# Altium schematic sheet Motor Drive.SchDoc
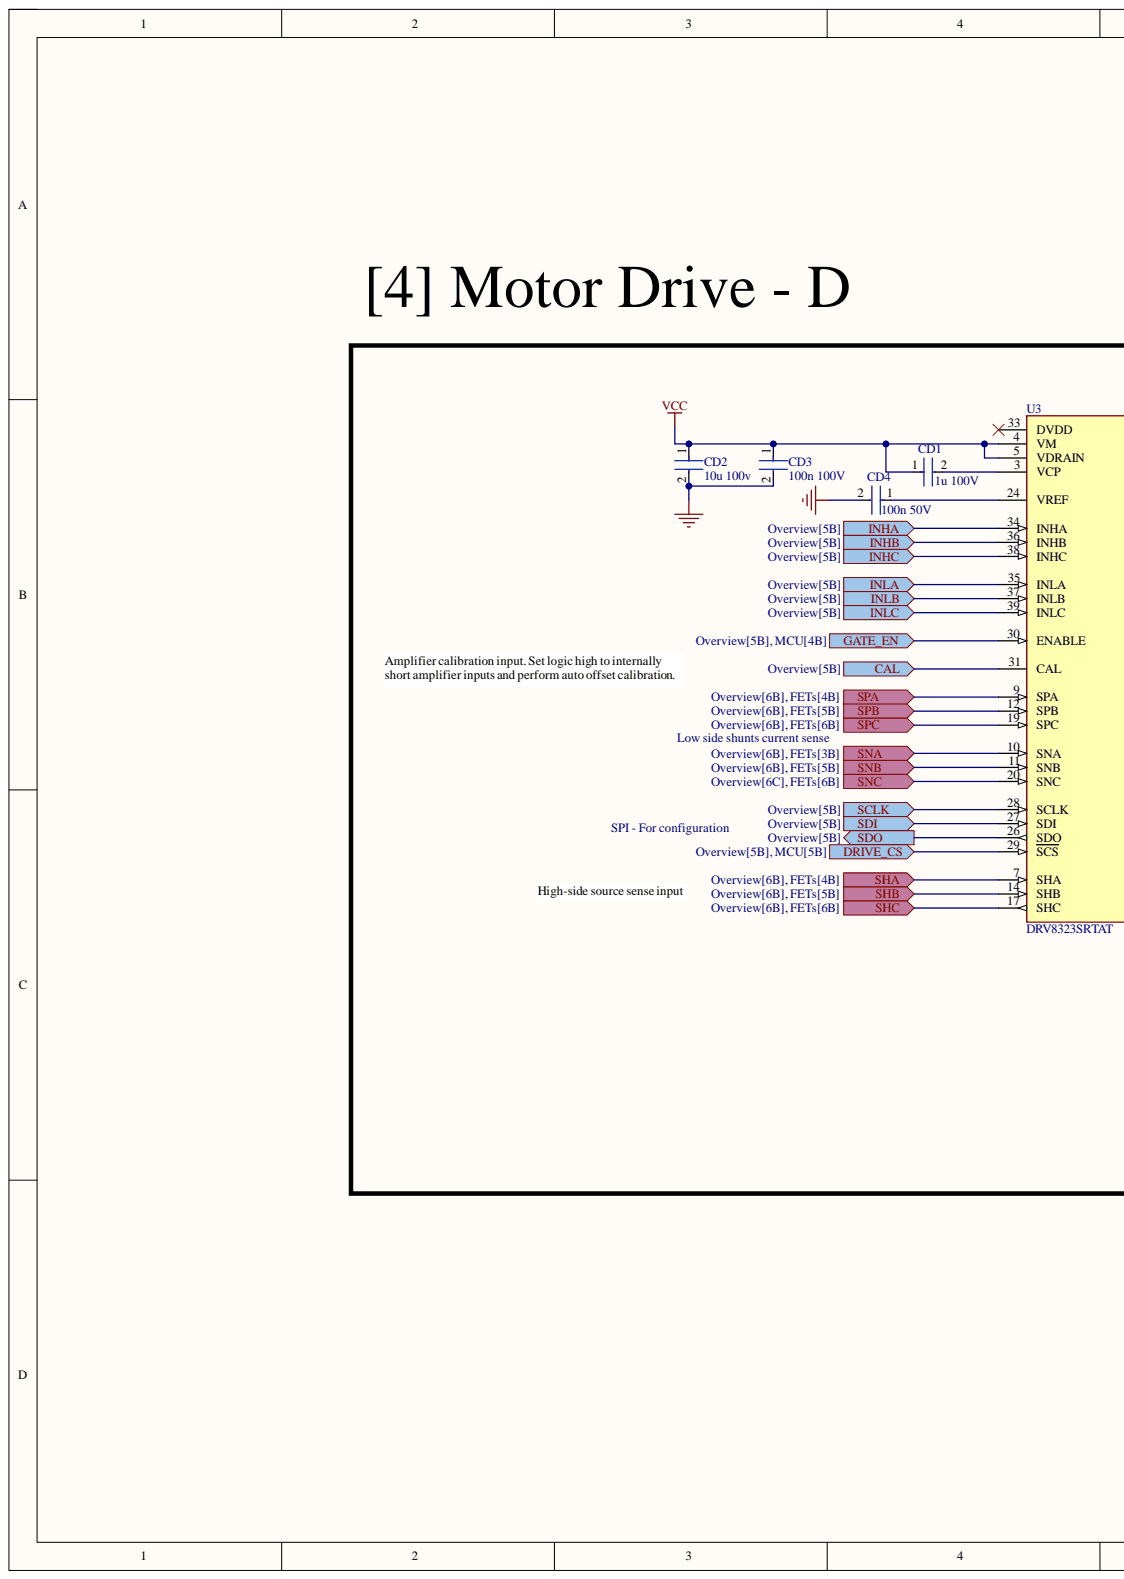
[diagram p0: Motor Drive.SchDoc, left]
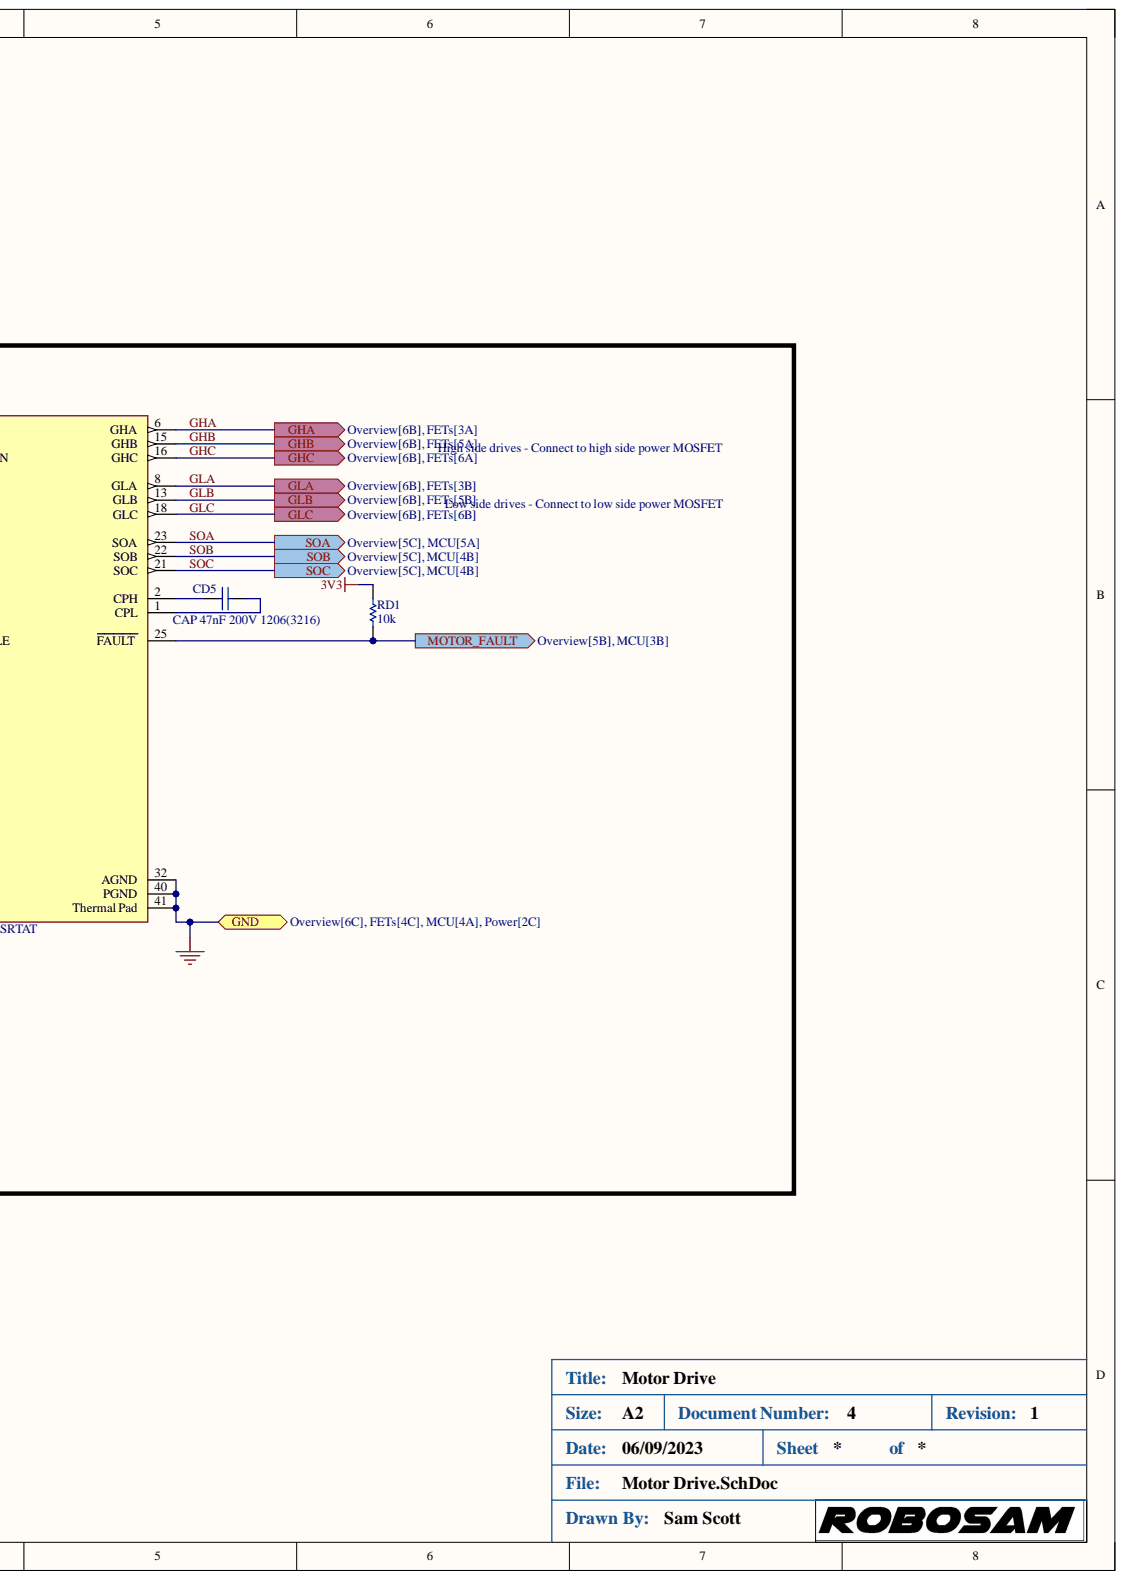
[diagram p1: Motor Drive.SchDoc, right]

## Parts
CD1  1u 100V  Voltage Rating: 100V  [FP-1206-L_3_2_0_15-W_1_6-IPC_A]
CD2  10u 100v  [FP-GRM32E-0_3-0_2-MFG]
CD3  100n 100V  Voltage Rating: 100V  [FP-CL21-IPC_A]
CD4  100n 50V  Voltage Rating: 50V  [FP-CL10-IPC_A]
CD5  CAP 47nF 200V 1206(3216)  value 47nF  [CAPC1206(3216)130_M]
RD1  10k  [RESC1609X50X30NL8T20]
U3  DRV8323SRTAT  [FP-RTA0040B-MFG]

## Ports
CAL, DRIVE_CS, GATE_EN, GHA, GHB, GHC, GLA, GLB, GLC, GND, INHA, INHB, INHC, INLA, INLB, INLC, MOTOR_FAULT, SCLK, SDI, SDO, SHA, SHB, SHC, SNA, SNB, SNC, SOA, SOB, SOC, SPA, SPB, SPC

## Nets
3V3: RD1.2
VCC: CD1.1 CD2.1 CD3.1 U3.4(VM) U3.5(VDRAIN)
CAL: U3.31(CAL) ; port CAL
DRIVE_CS: U3.29(SCS) ; port DRIVE_CS
GATE_EN: U3.30(ENABLE) ; port GATE_EN
GHA: U3.6(GHA) ; port GHA
GHB: U3.15(GHB) ; port GHB
GHC: U3.16(GHC) ; port GHC
GLA: U3.8(GLA) ; port GLA
GLB: U3.13(GLB) ; port GLB
GLC: U3.18(GLC) ; port GLC
GND: CD2.2 CD3.2 CD4.2 U3.32(AGND) U3.40(PGND) U3.41(Thermal_Pad) ; port GND
INHA: U3.34(INHA) ; port INHA
INHB: U3.36(INHB) ; port INHB
INHC: U3.38(INHC) ; port INHC
INLA: U3.35(INLA) ; port INLA
INLB: U3.37(INLB) ; port INLB
INLC: U3.39(INLC) ; port INLC
MOTOR_FAULT: RD1.1 U3.25(FAULT) ; port MOTOR_FAULT
SCLK: U3.28(SCLK) ; port SCLK
SDI: U3.27(SDI) ; port SDI
SDO: U3.26(SDO) ; port SDO
SHA: U3.7(SHA) ; port SHA
SHB: U3.14(SHB) ; port SHB
SHC: U3.17(SHC) ; port SHC
SNA: U3.10(SNA) ; port SNA
SNB: U3.11(SNB) ; port SNB
SNC: U3.20(SNC) ; port SNC
SOA: U3.23(SOA) ; port SOA
SOB: U3.22(SOB) ; port SOB
SOC: U3.21(SOC) ; port SOC
SPA: U3.9(SPA) ; port SPA
SPB: U3.12(SPB) ; port SPB
SPC: U3.19(SPC) ; port SPC
NetCD1_2: CD1.2 U3.3(VCP)
NetCD4_1: CD4.1 U3.24(VREF)
NetCD5_1: CD5.1 U3.2(CPH)
NetCD5_2: CD5.2 U3.1(CPL)

Not connected: U3 33(DVDD)

## Library data of the parts
CD1 = CMP-14477-001499-2: None; Capacitance: 1uF; Dielectric: X7R; Tolerance: 10%; Case/Package: 1206; Component Kind: Standard; Halogen Free: Halogen Free; Lead Free: Lead Free; Length: 0.126inch; Library Name: Altium Content Vault; Max Operating Temperature: 125°C; Min Operating Temperature: -55°C; Mount: Surface Mount; Packaging: Tape and Reel; Ratings: AEC-Q200; RoHS Compliant: Yes; Thickness: 0.071inch; Voltage Rating (DC): 100V; Width: 0.063inch
CD2 = CMP-06035-000081-2: Chip Multilayer Ceramic Capacitors for General Purpose, 1210, 10uF, X7S, 22%, 10%, 100V; Capacitance: 10uF; Case Code (Imperial): 1210; Case Code (Metric): 3225; Case/Package: 1210; Component Kind: Standard; Library Name: Altium Content Vault; Max Operating Temperature: 125°C; Min Operating Temperature: -55°C; Mount: Surface Mount; Packaging: Tape and Reel; Tolerance: 10%; Voltage Rating: 100V
CD3 = CMP-13271-000177-1: CL21 Series 0805 100nF 100V ±10% Tolerance X7R Multilayer Ceramic Chip Capacitor; Capacitance: 100nF; Case Code (Imperial): 0805; Case Code (Metric): 2012; Case/Package: 0805; Component Kind: Standard; Features: Soft Termination; Length: 0.079inch; Library Name: Altium Content Vault; Max Operating Temperature: 125°C; Min Operating Temperature: -55°C; Mount: Surface Mount; Packaging: Tape and Reel; Ratings: AEC-Q200; Thickness: 0.053inch; Tolerance: 10%; Width: 0.049inch
CD4 = CMP-13271-001703-1: Cap Ceramic 100nF 50V X7R ±10% Pad SMD 0603 +125°C T/R; Capacitance: 100nF; Case Code (Imperial): 0603; Case Code (Metric): 1608; Case/Package: 0603; Component Kind: Standard; Library Name: Altium Content Vault; Max Operating Temperature: 125°C; Min Operating Temperature: -55°C; Mount: Surface Mount; Packaging: Cut Tape; Ratings: AEC-Q200; Tolerance: 10%
CD5 = CMP-1037-04007-1: CAP 47nF 200V ±10% 1206 (3216 Metric) Thickness 1.3mm SMD; Case-EIA: 1206; Case-Metric: 3216; Tolerance: Â±10%; Component Kind: Standard; Library Name: Altium Content Vault; Max Thickness: 1.3 mm; Rated Voltage: 200 V; Technology: SMT
RD1 = CMP-1666-00005-4: Chip Resistor, 10 KOhm, +/- 1%, 100 mW, -55 to 155 degC, 0603 (1608 Metric), RoHS, Tape and Reel; Manufacturer: Vishay Semiconductor; Voltage Rating: 75 V; Component Kind: Standard; ComponentLink1Description: Manufacturer URL; ComponentLink1URL: http://www.vishay.com/; ComponentLink2Description: Datasheet; ComponentLink2URL: https://datasheet.ciiva.com/11809/0900766b80d98afc-11809037.pdf; DatasheetVersion: 06/2012; Height: 0.45 mm; Length: 1.55 mm; Library Name: Altium Content Vault; Max Operating Temperature: 155 degC; Min Operating Temperature: -55 degC; Mounting Technology: Surface Mount; Package Quantity: 5000; PackageDescription: 2-Pin Surface Mount Device, Body 1.575 x 0.85 mm; PackageReference: 0603; Packaging: Tape and Reel; REACH SVHC: Unknown; RoHSCompliant: true
U3 = CMP-04915-000019-1: IC MTR DRV MULTPHAS 6-60V 40WQFN; Interface: SPI; Output Current: 5mA; Component Kind: Standard; Library Name: Altium Content Vault; Max Operating Temperature: 125°C; Min Operating Temperature: -40°C; Mount: Surface Mount; Packaging: Tape and Reel; RoHS Compliant: Yes
